# Altium schematic sheet B2B_connectors.SchDoc(B2B_connectors)
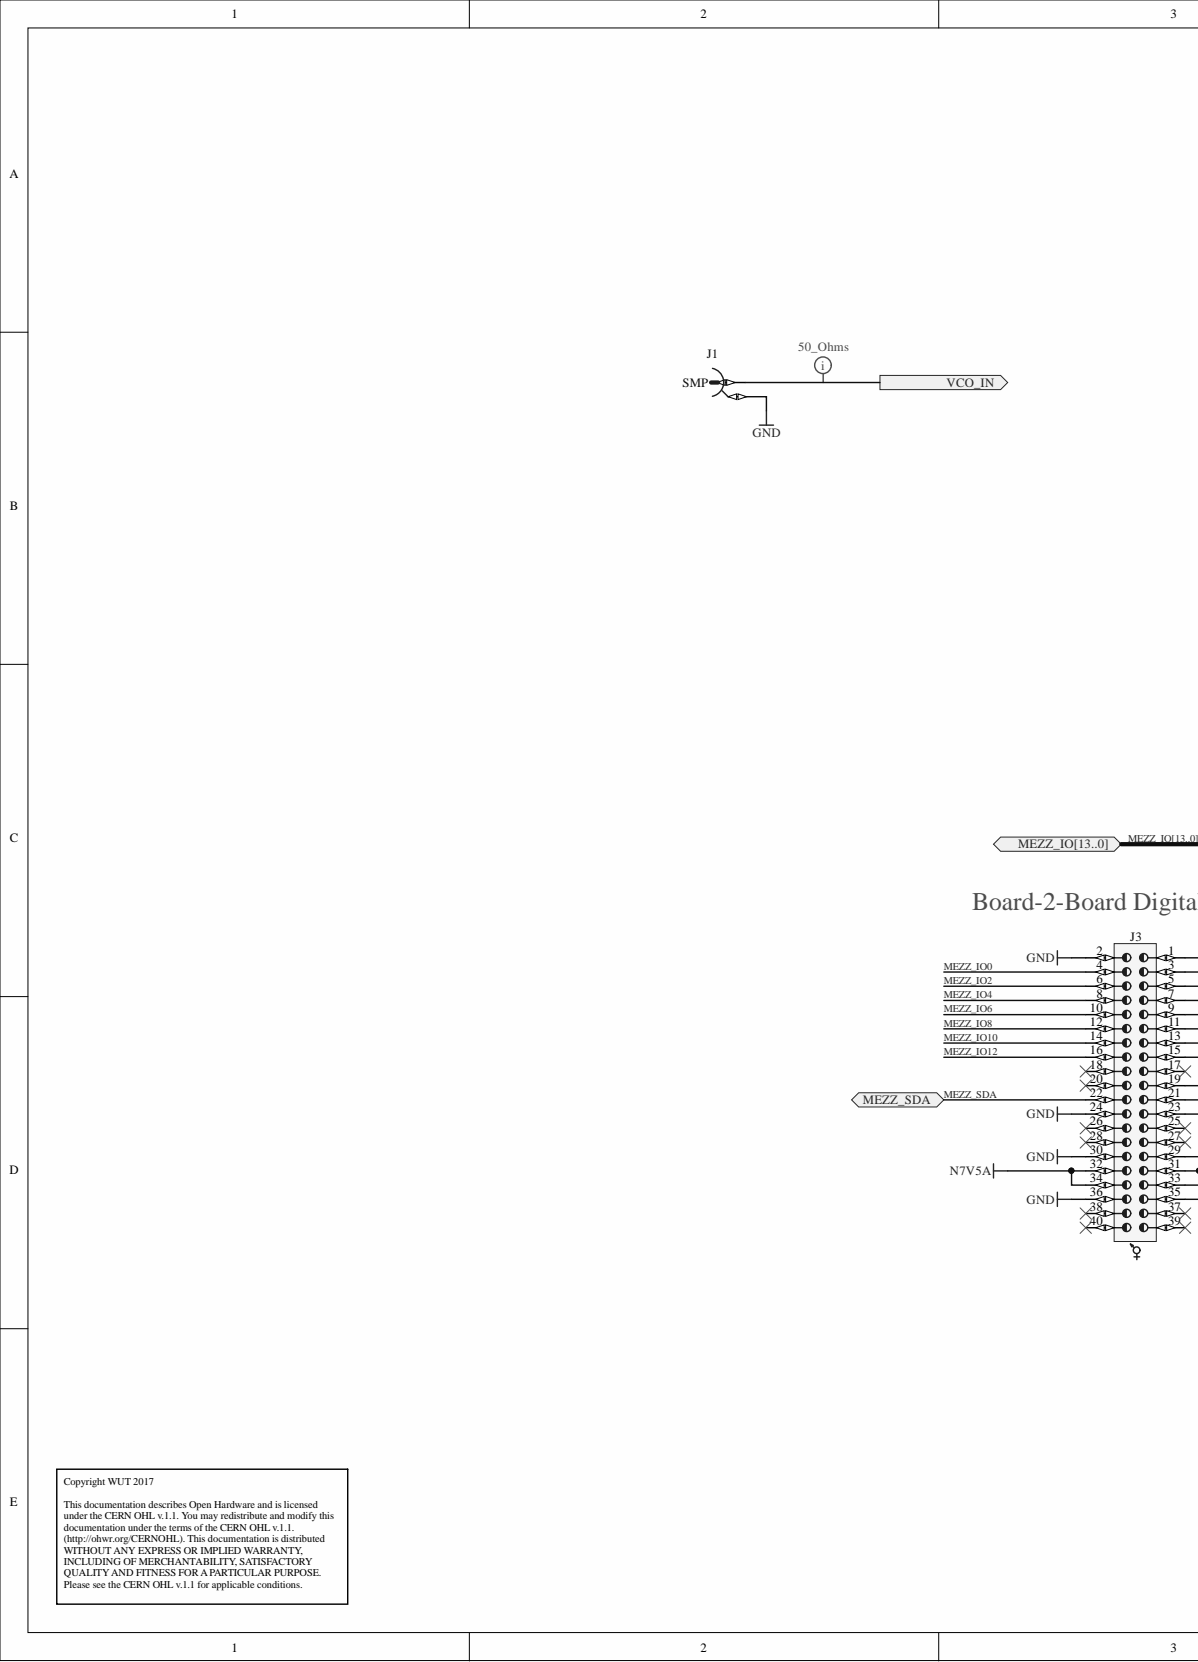
[diagram p0: B2B_connectors.SchDoc, left]
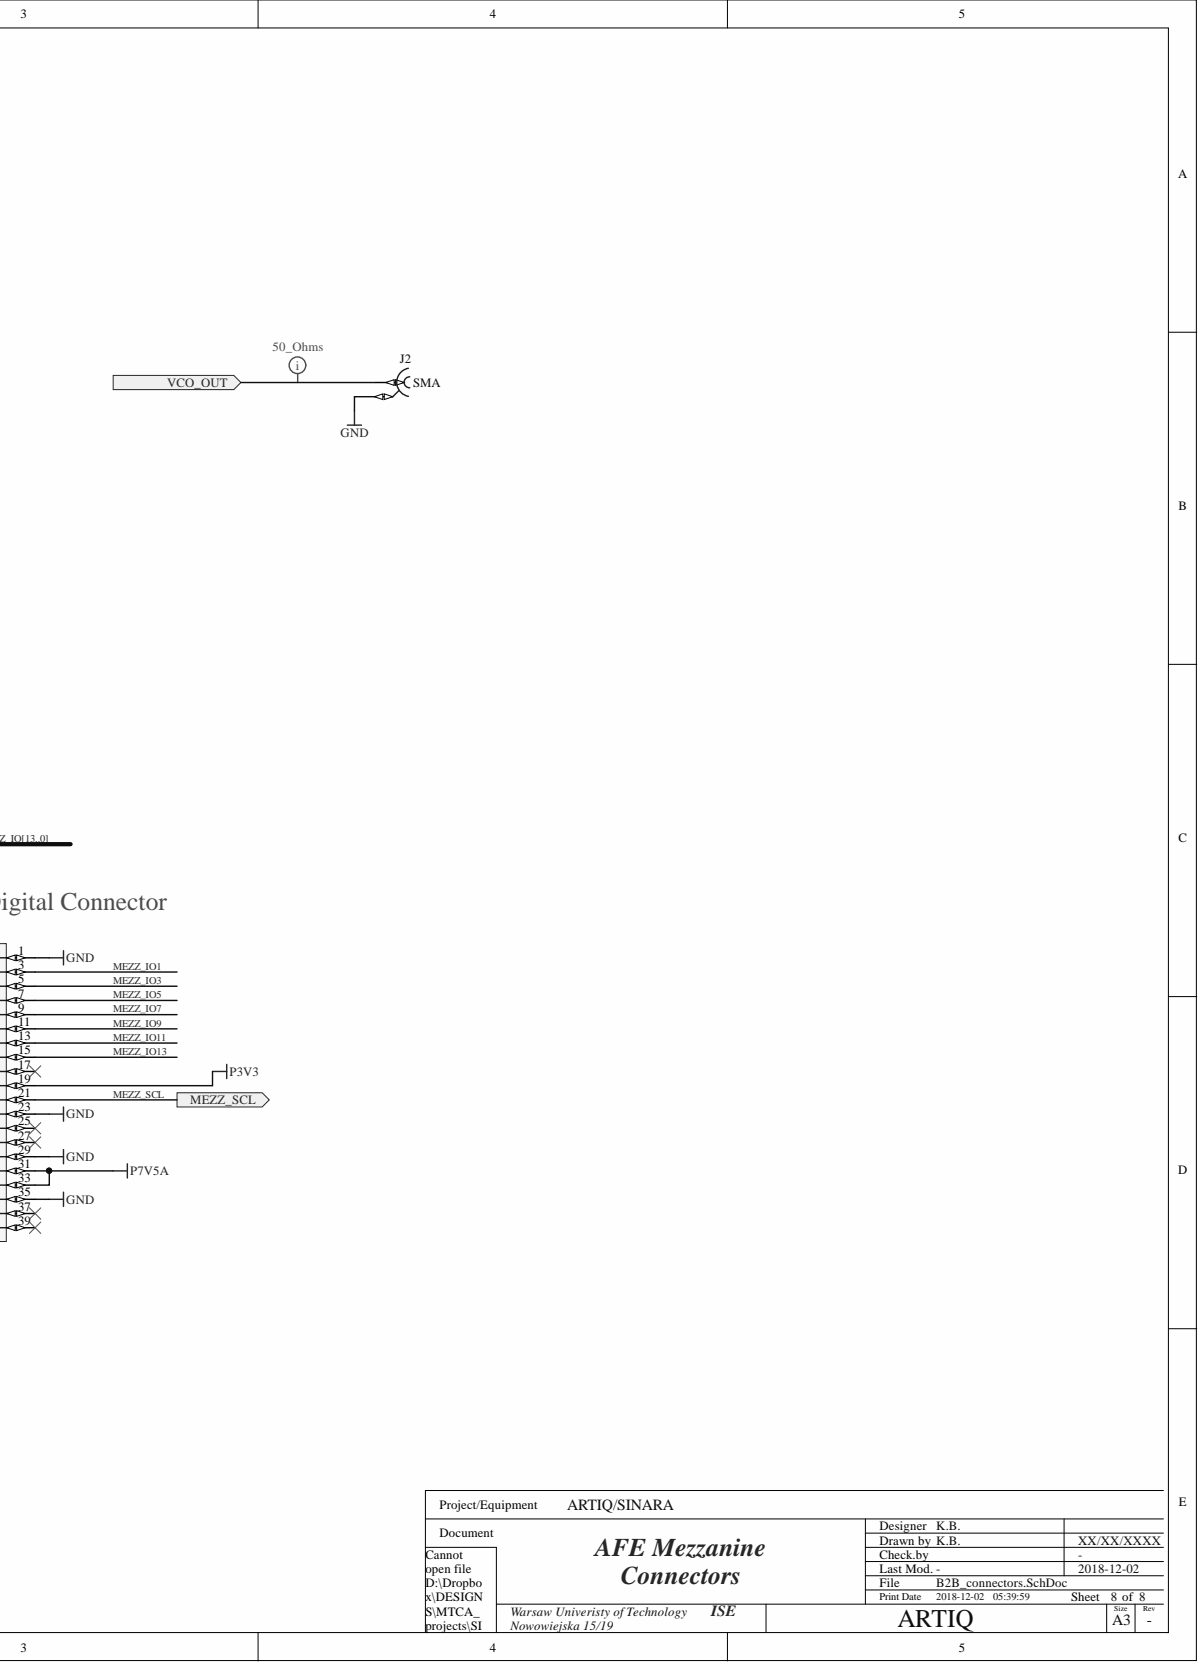
[diagram p1: B2B_connectors.SchDoc, right]

## Parts
J1  SMP  [MOLEX_85305-0232]
J2  SMA  [TELEGARTNER_J01151A0451]
J3  =Familly  [SAMTEC_LSS-120-02-L-DV-A]

## Ports
MEZZ_IO0, MEZZ_IO1, MEZZ_IO2, MEZZ_IO3, MEZZ_IO4, MEZZ_IO5, MEZZ_IO6, MEZZ_IO7, MEZZ_IO8, MEZZ_IO9, MEZZ_IO10, MEZZ_IO11, MEZZ_IO12, MEZZ_IO13, MEZZ_IO[13..0], MEZZ_SCL, MEZZ_SDA, VCO_IN, VCO_OUT

## Nets
GND: J1.2 J2.2 J3.1 J3.2 J3.23 J3.24 J3.29 J3.30 J3.35 J3.36
N7V5A: J3.32 J3.34
P3V3: J3.19
P7V5A: J3.31 J3.33
MEZZ_IO0: J3.4 ; port MEZZ_IO[13..0]  [also labelled MEZZ_IO]
MEZZ_IO1: J3.3 ; port MEZZ_IO[13..0]  [also labelled MEZZ_IO]
MEZZ_IO2: J3.6 ; port MEZZ_IO[13..0]  [also labelled MEZZ_IO]
MEZZ_IO3: J3.5 ; port MEZZ_IO[13..0]  [also labelled MEZZ_IO]
MEZZ_IO4: J3.8 ; port MEZZ_IO[13..0]  [also labelled MEZZ_IO]
MEZZ_IO5: J3.7 ; port MEZZ_IO[13..0]  [also labelled MEZZ_IO]
MEZZ_IO6: J3.10 ; port MEZZ_IO[13..0]  [also labelled MEZZ_IO]
MEZZ_IO7: J3.9 ; port MEZZ_IO[13..0]  [also labelled MEZZ_IO]
MEZZ_IO8: J3.12 ; port MEZZ_IO[13..0]  [also labelled MEZZ_IO]
MEZZ_IO9: J3.11 ; port MEZZ_IO[13..0]  [also labelled MEZZ_IO]
MEZZ_IO10: J3.14 ; port MEZZ_IO[13..0]  [also labelled MEZZ_IO]
MEZZ_IO11: J3.13 ; port MEZZ_IO[13..0]  [also labelled MEZZ_IO]
MEZZ_IO12: J3.16 ; port MEZZ_IO[13..0]  [also labelled MEZZ_IO]
MEZZ_IO13: J3.15 ; port MEZZ_IO[13..0]  [also labelled MEZZ_IO]
MEZZ_SCL: J3.21 ; port MEZZ_SCL
MEZZ_SDA: J3.22 ; port MEZZ_SDA
VCO_IN: J1.1 ; port VCO_IN
VCO_OUT: J2.1 ; port VCO_OUT

Not connected: J3 17 18 20 25 26 27 28 37 38 39 40

## Library data of the parts
J1 = MOLEX_85305-0232: Part Description: 50 Ohms, SMP Surface Mount Plug, Limited Detent; Manufacturer: MOLEX; PressFit: No; Status: None; Socket: No; SMD: Yes; Sense: No; Pin Count: 2; Part Number: MOLEX_85305-0232; Mounted: Yes; Manufacturer Part Number: 85305-0232; Library Name: Connectors.DbLib; LatestRevisionDate: 09.08.2016; HelpURL: \\cern.ch\dfs\Applications\Altium\Datasheets\MOLEX_85305-0232.pdf; Family: SMP; Database Table Name: MOLEX; CreateDate: 09.08.2016; Component Type: Standard; Component Kind: Standard; ComponentHeight: 4.1mm; Author: CERN DEM JMW
J2 = TELEGARTNER_J01151A0451: Part Description: SMA 50 ohms Edge Mount Bulkhead Jack Receptacle; PressFit: No; Database Table Name: Miscellaneous; Status: None; Socket: No; SMD: No; Sense: No; Pin Count: 2; Part Number: TELEGARTNER_J01151A0451; Mounted: Yes; Manufacturer Part Number: J01151A0451; Manufacturer: TELEGARTNER; Library Name: Connectors.DbLib; LatestRevisionDate: 27.10.2016; HelpURL: \\cern.ch\dfs\Applications\Altium\Datasheets\TELEGARTNER_J01151A0451.pdf; Family: SMA; CreateDate: 27.10.2016; Component Type: Standard; Component Kind: Standard; ComponentHeight: 7mm; Author: CERN DEM JLC
J3 = (no symbol name): 40 Contacts, Pitch 0.635mm, Razor Beam LSS Vertical High Speed Hermaphroditic Strip (Lead Style 7.49mm); Part Description: 40 Contacts, Pitch 0.635mm, Razor Beam LSS Vertical High Speed Hermaphroditic Strip (Lead Style 5.49mm); Manufacturer Part Number: LSS-120-02-L-DV-A; Manufacturer: SAMTEC; PressFit: No; Status: None; Socket: No; SMD: Yes; Sense: No; Pin Count: 40; Part Number: SAMTEC_LSS-120-02-L-DV-A; Mounted: Yes; Library Name: LIBRARY_SCH.SCHLIB; LatestRevisionDate: 10.11.2015; HelpURL: \\cern.ch\dfs\Applications\Altium\Datasheets\SAMTEC_LSS-120-03-L-DV-A.pdf; CreateDate: 10.11.2015; Component Type: Standard; Component Kind: Standard; ComponentHeight: 5.57mm; Author: CERN DEM JMW
